# Altium schematic sheet Sheet1.SchDoc(Sheet1)
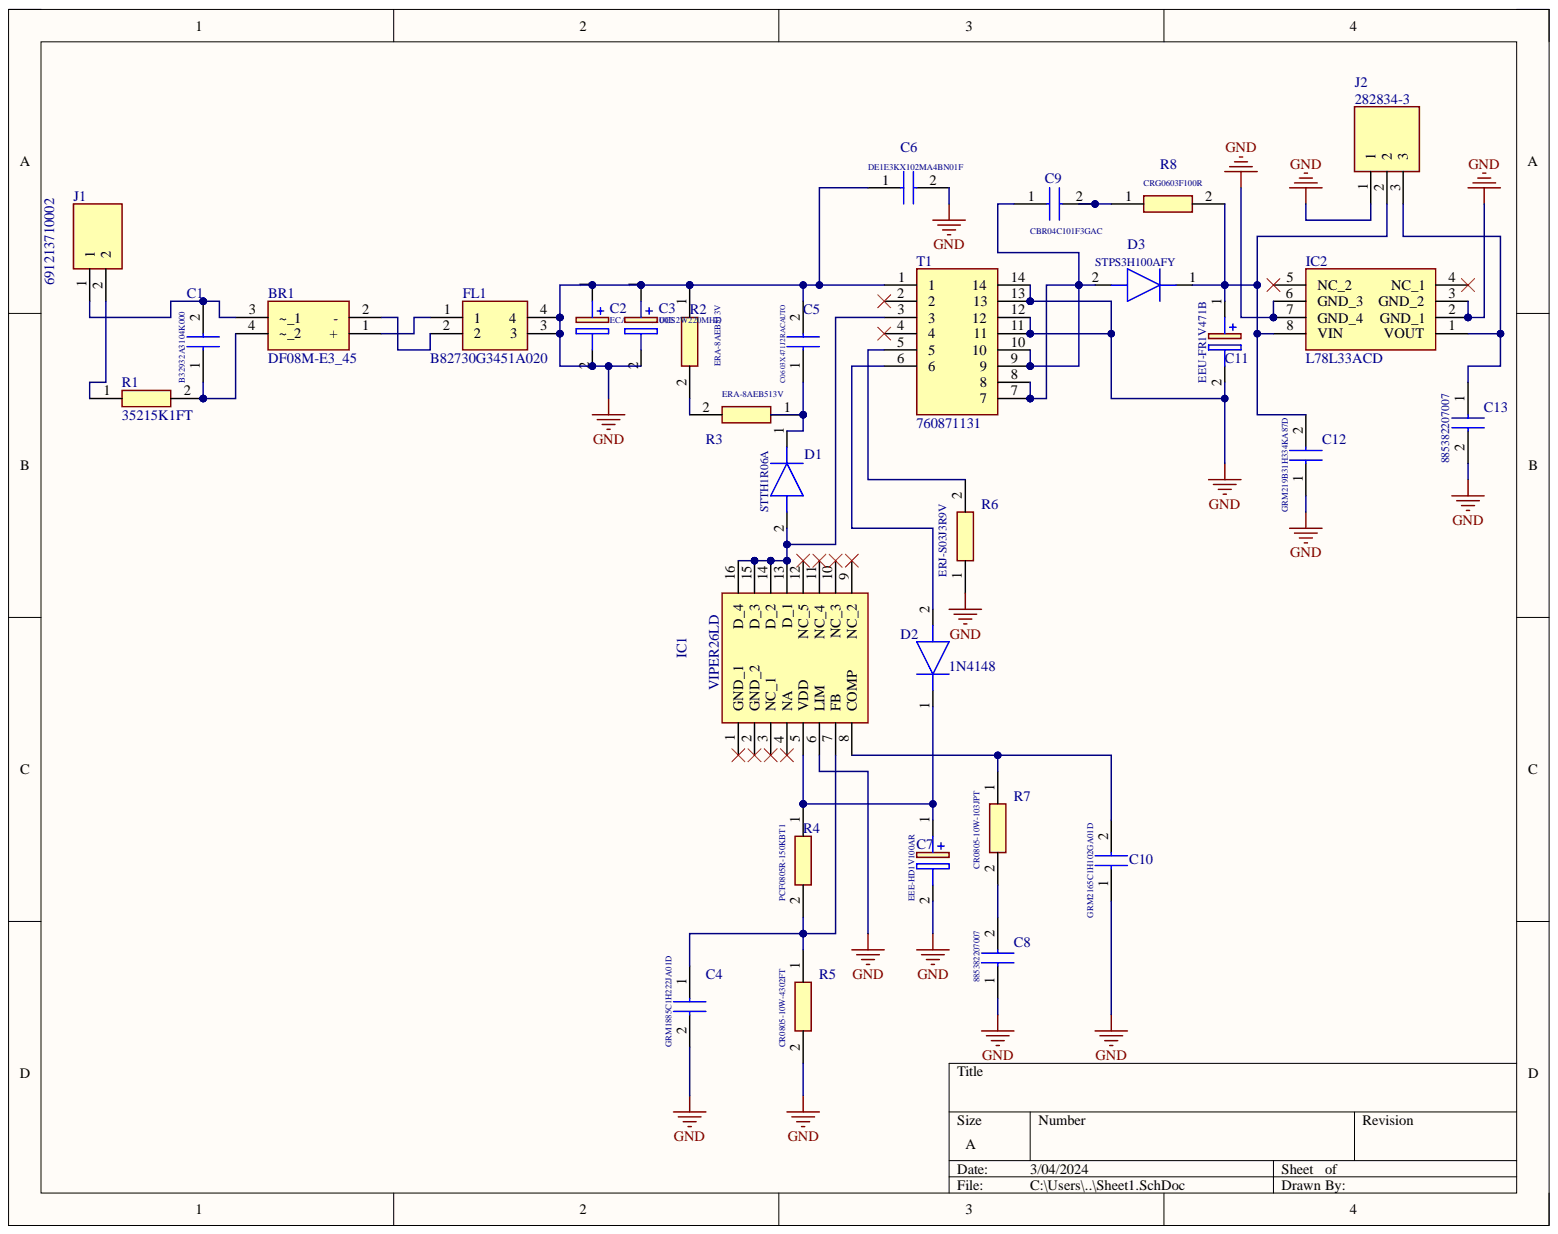
[diagram p0: Sheet1.SchDoc]

## Parts
BR1  DF08M-E3_45  [DIP825W58P510L832H533Q4N]
C1  B32932A3104K000  [B32932A_(18_x_6)]
C2  ECA-2WHG100E  [CAPPRD500W65D1250H2200]
C3  UCS2W220MHD  [CAPPRD750W80D1600H2200]
C4  GRM1885C1H222JA01D  [CAPC1608X90N]
C5  C0603X471J2RACAUTO  [C0603]
C6  DE1E3KX102MA4BN01F  [DE1E3KX102MA4BN01F]
C7  EEE-HD1V100AR  [CAPAE530X610N]
C8  885382207007  [CAPC2012X135N]
C9  CBR04C101F3GAC  [CBR04C160F5GAC]
C10  GRM2165C1H102GA01D  [CAPC2012X70N]
C11  EEU-FR1V471B  [CAPPRD500W65D1000H1750]
C12  GRM219B31H334KA87D  [CAPC2012X95N]
C13  885382207007  [CAPC2012X135N]
D1  STTH1R06A  [DIOM5126X265N]
D2  1N4148  [DIOAD1150W50L390D190]
D3  STPS3H100AFY  [SM6F22AY]
FL1  B82730G3451A020  [DIPS700W70P800L1650H1200Q4N]
IC1  VIPER26LD  [SOIC127P600X175-16N]
IC2  L78L33ACD  [SOIC127P600X175-8N]
J1  691213710002  [691213710002]
J2  282834-3  [2828343]
R1  35215K1FT  [RESC6432X65N]
R2  ERA-8AEB513V  [RESC3216X70N]
R3  ERA-8AEB513V  [RESC3216X70N]
R4  PCF0805R-150KBT1  [RESC2012X65N]
R5  CR0805-10W-4302FT  [CR0805-10W-4302FT]
R6  ERJ-S03J3R9V  [RESC1608X55N]
R7  CR0805-10W-103JPT  [CR0805-10W-103JPT]
R8  CRG0603F100R  [RESC1608X55N]
T1  760871131  [760871131]

## Nets
GND: C2.1 C2.2 C3.1 C3.2 C4.2 C5.2 C6.1 C6.2 C7.2 C8.1 C10.1 C11.2 C12.1 C13.2 FL1.3 FL1.4 IC1.6(LIM) IC2.2(GND_1) IC2.3(GND_2) IC2.6(GND_3) IC2.7(GND_4) J2.1 R2.1 R5.2 R6.1 T1.1 T1.11 T1.12 T1.13 T1.14
NetBR1_1: BR1.1(+) FL1.1
NetBR1_2: BR1.2(-) FL1.2
NetBR1_3: BR1.3(~_1) C1.2 J1.1
NetBR1_4: BR1.4(~_2) C1.1 R1.2
NetC4_1: C4.1 IC1.7(FB) R4.2 R5.1
NetC5_1: C5.1 D1.1 R3.1
NetC7_1: C7.1 D2.1 IC1.5(VDD) R4.1
NetC8_2: C8.2 R7.2
NetC9_1: C9.1 D3.2 T1.7 T1.8 T1.9 T1.10
NetC9_2: C9.2 R8.1
NetC10_2: C10.2 IC1.8(COMP) R7.1
NetC11_1: C11.1 C12.2 D3.1 IC2.8(VIN) J2.2 R8.2
NetC13_1: C13.1 IC2.1(VOUT) J2.3
NetD1_2: D1.2 IC1.13(D_1) IC1.14(D_2) IC1.15(D_3) IC1.16(D_4) T1.3
NetD2_2: D2.2 T1.6
NetJ1_2: J1.2 R1.1
NetR2_2: R2.2 R3.2
NetR6_2: R6.2 T1.5

Not connected: IC1 1(GND_1) 2(GND_2) 3(NC_1) 4(NA) 9(NC_2) 10(NC_3) 11(NC_4) 12(NC_5); IC2 4(NC_1) 5(NC_2); T1 2 4

## Library data of the parts
BR1 = DF08M-E3_45: Bridge Rectifier; Manufacturer_Name: Vishay; Manufacturer_Part_Number: DF08M-E3/45; Arrow Part Number: DF08M-E3/45; Arrow Price/Stock: https://www.arrow.com/en/products/df08m-e345/vishay?region=nac; Component Kind: Standard; Datasheet Link: https://www.vishay.com/docs/88571/dfm.pdf; Height: 5.33mm; Mouser Part Number: 625-DF08M-E3; Mouser Price/Stock: https://www.mouser.co.uk/ProductDetail/Vishay-General-Semiconductor/DF08M-E3-45?qs=lTgc38YOCCpR8QbbedHDzA%3D%3D
C1 = B32932A3104K000: Capacitor; Manufacturer_Name: TDK; Arrow Part Number: B32932A3104K000; Arrow Price/Stock: https://www.arrow.com/en/products/b32932a3104k000/epcos-tdk; Component Kind: Standard; Datasheet Link: https://product.tdk.com/system/files/dam/doc/product/capacitor/film/emi/data_sheet/20/20/ds/mkt_b32932_936.pdf; Manufacturer_Part_Number: B32932A3104K000; Mouser Part Number: 871-B32932A3104K000; Mouser Price/Stock: https://www.mouser.co.uk/ProductDetail/EPCOS-TDK/B32932A3104K000?qs=7DnIVh14JBsvjQl1C4wSrg%3D%3D
C2 = ECA-2WHG100E: Capacitor Polarised; Manufacturer_Name: Panasonic; Component Kind: Standard; Datasheet Link: http://industrial.panasonic.com/cdbs/www-data/pdf/RDF0000/ABA0000C1215.pdf; Height: 22mm; Manufacturer_Part_Number: ECA-2WHG100E; Mouser Part Number: 667-ECA-2WHG100E; Mouser Price/Stock: https://www.mouser.co.uk/ProductDetail/Panasonic/ECA-2WHG100E?qs=rMMd5vBiahqThL%252BNhsuRMQ%3D%3D
C3 = UCS2W220MHD: Capacitor Polarised; Manufacturer_Name: Nichicon; Arrow Part Number: UCS2W220MHD; Arrow Price/Stock: https://www.arrow.com/en/products/ucs2w220mhd/nichicon?region=nac; Component Kind: Standard; Datasheet Link: https://componentsearchengine.com/Datasheets/1/UCS2W220MHD.pdf; Height: 22mm; Manufacturer_Part_Number: UCS2W220MHD; Mouser Part Number: 647-UCS2W220MHD; Mouser Price/Stock: https://www.mouser.co.uk/ProductDetail/Nichicon/UCS2W220MHD?qs=OpdMUBmMlYZ5NNhZioB2yQ%3D%3D
C4 = GRM1885C1H222JA01D: Capacitor; Manufacturer_Name: Murata Electronics; Arrow Part Number: GRM1885C1H222JA01D; Arrow Price/Stock: https://www.arrow.com/en/products/grm1885c1h222ja01d/murata-manufacturing; Component Kind: Standard; Datasheet Link: https://psearch.en.murata.com/capacitor/product/GRM1885C1H222JA01%23.html; Height: 0.9mm; Manufacturer_Part_Number: GRM1885C1H222JA01D; Mouser Part Number: 81-GRM1885C1H222JA01; Mouser Price/Stock: https://www.mouser.co.uk/ProductDetail/Murata-Electronics/GRM1885C1H222JA01D?qs=LTSJzWPhWVwLnVjr0tf7BQ%3D%3D
C5 = C0603X471J2RACAUTO: Capacitor; Manufacturer_Name: KEMET; Component Kind: Standard; Datasheet Link: https://content.kemet.com/datasheets/KEM_C1078_X7R_FT-CAP_AUTO_SMD.pdf; Height: 0.87mm; Manufacturer_Part_Number: C0603X471J2RACAUTO
C6 = DE1E3KX102MA4BN01F: Capacitor; Manufacturer_Name: Murata Electronics; Arrow Part Number: DE1E3KX102MA4BN01F; Arrow Price/Stock: https://www.arrow.com/en/products/de1e3kx102ma4bn01f/murata-manufacturing; Component Kind: Standard; Datasheet Link: https://componentsearchengine.com/Datasheets/2/DE1E3KX102MA4BN01F.pdf; Height: 10mm; Manufacturer_Part_Number: DE1E3KX102MA4BN01F; Mouser Part Number: 81-DE1E3KX102MA4BN1F; Mouser Price/Stock: https://www.mouser.co.uk/ProductDetail/Murata-Electronics/DE1E3KX102MA4BN01F?qs=P0q0QPXdz8CkCUbt0WrKjA%3D%3D
C7 = EEE-HD1V100AR: Capacitor Polarised; Manufacturer_Name: Panasonic; Arrow Part Number: EEE-HD1V100AR; Arrow Price/Stock: https://www.arrow.com/en/products/eee-hd1v100ar/panasonic; Component Kind: Standard; Datasheet Link: http://industrial.panasonic.com/cdbs/www-data/pdf/RDE0000/ABA0000C1163.pdf; Height: 6.1mm; Manufacturer_Part_Number: EEE-HD1V100AR; Mouser Part Number: 667-EEE-HD1V100AR; Mouser Price/Stock: https://www.mouser.co.uk/ProductDetail/Panasonic/EEE-HD1V100AR?qs=bvTRKOZcE5fZp%2FesLhAOsQ%3D%3D
C8 C13 = 885382207007: Capacitor; Manufacturer_Name: Wurth Elektronik; Component Kind: Standard; Datasheet Link: https://katalog.we-online.com/pbs/datasheet/885382207007.pdf; Height: 1.35mm; Manufacturer_Part_Number: 885382207007; Mouser Part Number: 710-885382207007; Mouser Price/Stock: https://www.mouser.co.uk/ProductDetail/Wurth-Elektronik/885382207007?qs=0lSvoLzn4L%2FSz5irZYcG7Q%3D%3D
C9 = CBR04C101F3GAC: Capacitor; Manufacturer_Name: KEMET; Arrow Part Number: CBR04C101F3GAC; Arrow Price/Stock: https://www.arrow.com/en/products/cbr04c101f3gac/kemet-corporation?region=nac; Component Kind: Standard; Datasheet Link: http://www.kemet.com/Lists/ProductCatalog/Attachments/490/KEM_C1030_CBR_SMD.pdf; Height: 0.55mm; Manufacturer_Part_Number: CBR04C101F3GAC; Mouser Part Number: 80-CBR04C101F3GAC; Mouser Price/Stock: https://www.mouser.co.uk/ProductDetail/KEMET/CBR04C101F3GAC?qs=zoGAYOF739DI3FKRQE3E6g%3D%3D
C10 = GRM2165C1H102GA01D: Capacitor; Manufacturer_Name: Murata Electronics; Component Kind: Standard; Datasheet Link: http://www.murata.com/~/media/webrenewal/support/library/catalog/products/capacitor/mlcc/c02e.pdf; Height: 0.7mm; Manufacturer_Part_Number: GRM2165C1H102GA01D; Mouser Part Number: 81-GRM40C102G50D; Mouser Price/Stock: https://www.mouser.com/Search/Refine.aspx?Keyword=81-GRM40C102G50D
C11 = EEU-FR1V471B: Capacitor Polarised; Manufacturer_Name: Panasonic; Arrow Part Number: EEU-FR1V471B; Arrow Price/Stock: https://www.arrow.com/en/products/eeu-fr1v471b/panasonic?region=nac; Component Kind: Standard; Datasheet Link: http://industrial.panasonic.com/cdbs/www-data/pdf/RDF0000/ABA0000C1259.pdf; Height: 17.5mm; Manufacturer_Part_Number: EEU-FR1V471B; Mouser Part Number: 667-EEU-FR1V471B; Mouser Price/Stock: https://www.mouser.co.uk/ProductDetail/Panasonic/EEU-FR1V471B?qs=n1SRcuzDZT3ftWy1qYjNlA%3D%3D
C12 = GRM219B31H334KA87D: Capacitor; Manufacturer_Name: Murata Electronics; Component Kind: Standard; Datasheet Link: http://www.murata.com/~/media/webrenewal/support/library/catalog/products/capacitor/mlcc/c02e.pdf; Height: 0.95mm; Manufacturer_Part_Number: GRM219B31H334KA87D; Mouser Part Number: N/A; Mouser Price/Stock: https://www.mouser.com/Search/Refine.aspx?Keyword=N%2FA
D1 = STTH1R06A: Diode; Manufacturer_Name: STMicroelectronics; Arrow Part Number: STTH1R06A; Arrow Price/Stock: https://www.arrow.com/en/products/stth1r06a/stmicroelectronics?region=nac; Component Kind: Standard; Datasheet Link: http://www.st.com/web/en/resource/technical/document/datasheet/CD00005135.pdf; Height: 2.65mm; Manufacturer_Part_Number: STTH1R06A; Mouser Part Number: 511-STTH1R06A; Mouser Price/Stock: https://www.mouser.co.uk/ProductDetail/STMicroelectronics/STTH1R06A?qs=kndcJBrKlnTzl3o%252BhwomPg%3D%3D
D2 = 1N4148: Diode; Manufacturer_Name: Diotec; Arrow Part Number: 1N4148; Arrow Price/Stock: https://www.arrow.com/en/products/1n4148/diotec-semiconductor-ag; Component Kind: Standard; Datasheet Link: https://diotec.com/request/datasheet/1n4148.pdf; Manufacturer_Part_Number: 1N4148; Mouser Part Number: 637-1N4148; Mouser Price/Stock: https://www.mouser.co.uk/ProductDetail/Diotec-Semiconductor/1N4148?qs=OlC7AqGiEDmWf1%2FPHy84oA%3D%3D
D3 = STPS3H100AFY: Diode; Manufacturer_Name: STMicroelectronics; Arrow Part Number: STPS3H100AFY; Arrow Price/Stock: https://www.arrow.com/en/products/stps3h100afy/stmicroelectronics?region=nac; Component Kind: Standard; Datasheet Link: http://www.st.com/content/ccc/resource/technical/document/datasheet/group3/f8/8b/d8/d1/3a/01/46/86/DM00303209/files/DM00303209.pdf/jcr:content/translations/en.DM00303209.pdf; Height: 1.03mm; Manufacturer_Part_Number: STPS3H100AFY; Mouser Part Number: 511-STPS3H100AFY; Mouser Price/Stock: https://www.mouser.co.uk/ProductDetail/STMicroelectronics/STPS3H100AFY?qs=NoqMqJKvPFJEbekrsjbDug%3D%3D
FL1 = B82730G3451A020: Filter; Manufacturer_Name: TDK; Component Kind: Standard; Datasheet Link: https://product.tdk.com/system/files/dam/doc/product/emc/emc/line-filter/data_sheet/30/db/ind_2008/b82730u_g.pdf; Height: 12mm; Library Name: SamacSys.SchLib; Manufacturer_Part_Number: B82730G3451A020; Mouser Part Number: 871-B82730G3451A20; Mouser Price/Stock: https://www.mouser.com/Search/Refine.aspx?Keyword=871-B82730G3451A20
IC1 = VIPER26LD: Integrated Circuit; Manufacturer_Name: STMicroelectronics; Arrow Part Number: VIPER26LD; Arrow Price/Stock: https://www.arrow.com/en/products/viper26ld/stmicroelectronics; Component Kind: Standard; Datasheet Link: http://www.st.com/web/en/resource/technical/document/datasheet/CD00279018.pdf; Height: 1.75mm; Manufacturer_Part_Number: VIPER26LD; Mouser Part Number: 511-VIPER26LD; Mouser Price/Stock: https://www.mouser.co.uk/ProductDetail/STMicroelectronics/VIPER26LD?qs=%252BPn7Q6gPponPcy4dVDzF6g%3D%3D
IC2 = L78L33ACD: Integrated Circuit; Manufacturer_Name: STMicroelectronics; Component Kind: Standard; Datasheet Link: http://www.st.com/st-web-ui/static/active/en/resource/technical/document/datasheet/CD00000446.pdf; Height: 1.75mm; Manufacturer_Part_Number: L78L33ACD; Mouser Part Number: 511-L78L33ACD; Mouser Price/Stock: https://www.mouser.com/Search/Refine.aspx?Keyword=511-L78L33ACD
J1 = 691213710002: Connector; Manufacturer_Name: Wurth Elektronik; Component Kind: Standard; Datasheet Link: https://docs.rs-online.com/5993/0900766b8133a1df.pdf; Height: 10.2mm; Manufacturer_Part_Number: 691213710002; Mouser Part Number: 710-691213710002; Mouser Price/Stock: https://www.mouser.co.uk/ProductDetail/Wurth-Elektronik/691213710002?qs=7gQLVZk5cPkuKXksY6RqhA%3D%3D
J2 = 282834-3: Connector; Manufacturer_Name: TE Connectivity; Arrow Part Number: 282834-3; Arrow Price/Stock: https://www.arrow.com/en/products/282834-3/te-connectivity?region=europe; Component Kind: Standard; Datasheet Link: https://www.te.com/commerce/DocumentDelivery/DDEController?Action=showdoc&DocId=Customer+Drawing%7F282834%7FC1%7Fpdf%7FEnglish%7FENG_CD_282834_C1.pdf%7F282834-3; Height: 10mm; Manufacturer_Part_Number: 282834-3; Mouser Part Number: 571-2828343; Mouser Price/Stock: https://www.mouser.co.uk/ProductDetail/TE-Connectivity/282834-3?qs=A%252Bip%252BNCYi6M69kAjqV38yA%3D%3D
R1 = 35215K1FT: Resistor; Manufacturer_Name: TE Connectivity; Component Kind: Standard; Datasheet Link: https://www.te.com/commerce/DocumentDelivery/DDEController?Action=srchrtrv&DocNm=9-1773463-5&DocType=DS&DocLang=English; Height: 0.65mm; Manufacturer_Part_Number: 35215K1FT; Mouser Part Number: 279-35215K1FT; Mouser Price/Stock: https://www.mouser.com/Search/Refine.aspx?Keyword=279-35215K1FT
R2 R3 = ERA-8AEB513V: Resistor; Manufacturer_Name: Panasonic; Arrow Part Number: ERA-8AEB513V; Arrow Price/Stock: https://www.arrow.com/en/products/era8aeb513v/panasonic?region=nac; Component Kind: Standard; Datasheet Link: https://industrial.panasonic.com/cdbs/www-data/pdf/RDM0000/AOA0000C307.pdf; Height: 0.7mm; Manufacturer_Part_Number: ERA-8AEB513V; Mouser Part Number: 667-ERA-8AEB513V; Mouser Price/Stock: https://www.mouser.co.uk/ProductDetail/Panasonic/ERA-8AEB513V?qs=Ojoo2rCVP9SlNbGq1EFD8g%3D%3D
R4 = PCF0805R-150KBT1: Resistor; Manufacturer_Name: TT Electronics; Component Kind: Standard; Datasheet Link: https://componentsearchengine.com/Datasheets/1/PCF0805-13-10KBT1.pdf; Height: 0.65mm; Manufacturer_Part_Number: PCF0805R-150KBT1; Mouser Part Number: 756-PCF0805R-150KBT1; Mouser Price/Stock: https://www.mouser.co.uk/ProductDetail/Welwyn-Components-TT-Electronics/PCF0805R-150KBT1?qs=orEPegL0vw8RzaCSks%2FYpQ%3D%3D
R5 = CR0805-10W-4302FT: Resistor; Manufacturer_Name: Venkel; Component Kind: Standard; Datasheet Link: https://www.venkel.com/CR0805-8W-9763DT; Height: 0.6mm; Manufacturer_Part_Number: CR0805-10W-4302FT
R6 = ERJ-S03J3R9V: Resistor; Manufacturer_Name: Panasonic; Component Kind: Standard; Datasheet Link: https://industrial.panasonic.com/cdbs/www-data/pdf/RDP0000/AOA0000C334.pdf; Height: 0.55mm; Manufacturer_Part_Number: ERJ-S03J3R9V
R7 = CR0805-10W-103JPT: Resistor; Manufacturer_Name: Venkel; Component Kind: Standard; Datasheet Link: https://www.venkel.com/CR0805-8W-9763DT; Height: 0.6mm; Manufacturer_Part_Number: CR0805-10W-103JPT
R8 = CRG0603F100R: Resistor; Manufacturer_Name: TE Connectivity; Arrow Part Number: CRG0603F100R; Arrow Price/Stock: https://www.arrow.com/en/products/crg0603f100r/te-connectivity; Component Kind: Standard; Datasheet Link: http://docs-europe.electrocomponents.com/webdocs/13d1/0900766b813d116f.pdf; Height: 0.55mm; Manufacturer_Part_Number: CRG0603F100R; Mouser Part Number: 279-CRG0603F100R; Mouser Price/Stock: https://www.mouser.com/Search/Refine.aspx?Keyword=279-CRG0603F100R
T1 = 760871131: Transformer; Manufacturer_Name: Wurth Elektronik; Component Kind: Standard; Datasheet Link: https://www.mouser.com/datasheet/2/445/760871131-364.pdf; Height: 16mm; Manufacturer_Part_Number: 760871131; Mouser Part Number: 710-760871131; Mouser Price/Stock: https://www.mouser.co.uk/ProductDetail/Wurth-Elektronik/760871131?qs=AXuZnX5ayYTGaEpo71EunA%3D%3D
